# Altium schematic sheet breeze_motor.SchDoc
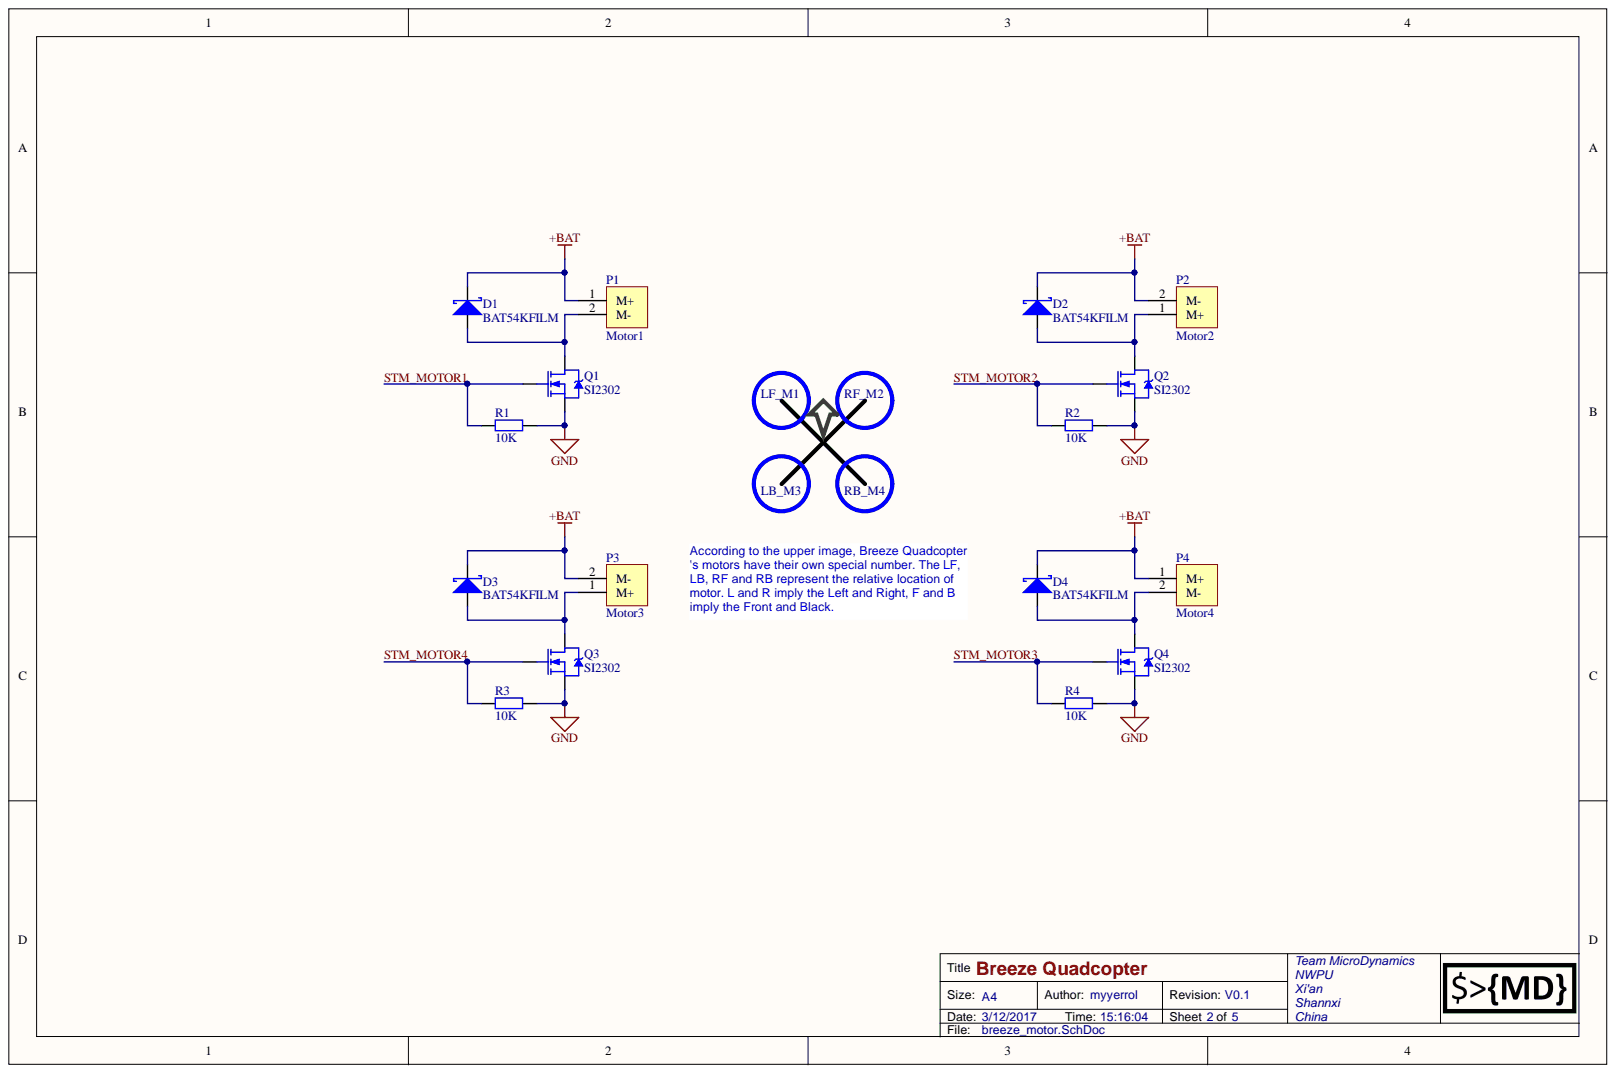
[diagram p0: breeze_motor.SchDoc]

## Parts
D1  BAT54KFILM  [SOD-523]
D2  BAT54KFILM  [SOD-523]
D3  BAT54KFILM  [SOD-523]
D4  BAT54KFILM  [SOD-523]
P1  Motor1  [HDRM-1X2]
P2  Motor2  [HDRM-1X2]
P3  Motor3  [HDRM-1X2]
P4  Motor4  [HDRM-1X2]
Q1  SI2302  [SOT-23]
Q2  SI2302  [SOT-23]
Q3  SI2302  [SOT-23]
Q4  SI2302  [SOT-23]
R1  value 10K  [SMD-0603]  (hidden comment: RES-0603)
R2  value 10K  [SMD-0603]  (hidden comment: RES-0603)
R3  value 10K  [SMD-0603]  (hidden comment: RES-0603)
R4  value 10K  [SMD-0603]  (hidden comment: RES-0603)

## Nets
+BAT: D1.2 D2.2 D3.2 D4.2 P1.1 P2.2 P3.2 P4.1
GND: Q1.2 Q2.2 Q3.2 Q4.2 R1.2 R2.2 R3.2 R4.2
STM_MOTOR1: Q1.1 R1.1
STM_MOTOR2: Q2.1 R2.1
STM_MOTOR3: Q4.1 R4.1
STM_MOTOR4: Q3.1 R3.1
NetD1_1: D1.1 P1.2 Q1.3
NetD2_1: D2.1 P2.1 Q2.3
NetD3_1: D3.1 P3.1 Q3.3
NetD4_1: D4.1 P4.2 Q4.3

## Library data of the parts
D1-D4 = BAT54KFILM: Schottky Diode; Component Kind: Standard; Library Name: breeze_design_library.SchLib
P1 P4 = Motor_A: Motor Header; Component Kind: Standard; Library Name: breeze_design_library.SchLib
P2 P3 = Motor_B: Motor Header; Component Kind: Standard; Library Name: breeze_design_library.SchLib
Q1-Q4 = SI2302: N-Channel Enhancement Mode Field Effect Transistor; Component Kind: Standard; Library Name: breeze_design_library.SchLib
R1-R4 = RES-0603: Resistor; Component Kind: Standard; Library Name: breeze_design_library.SchLib
